# Altium schematic sheet ICWSHLP2_Adaptor_2x2_Transimpedance_ASIC0.SchDoc(ICWSHLP2_Adaptor_2x2_Transimpedance_ASIC0)
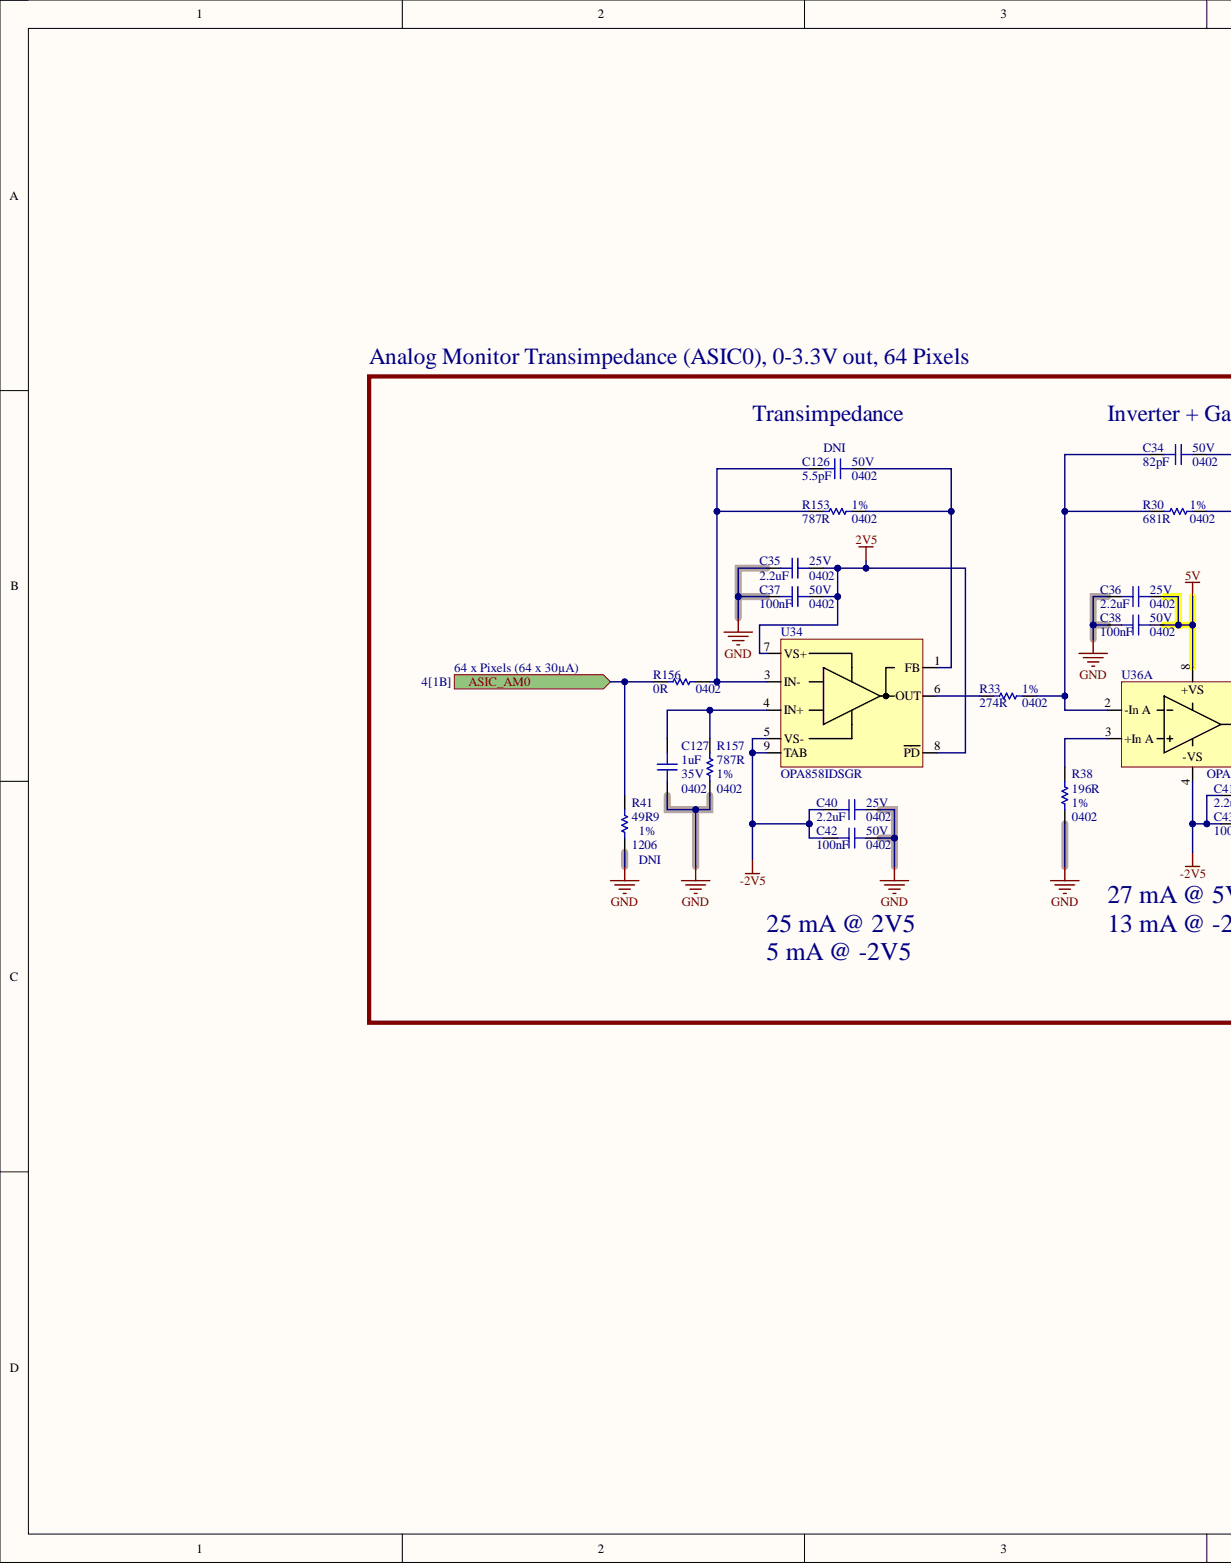
[diagram p0: ICWSHLP2_Adaptor_2x2_Transimpedance_ASIC0.SchDoc, left]
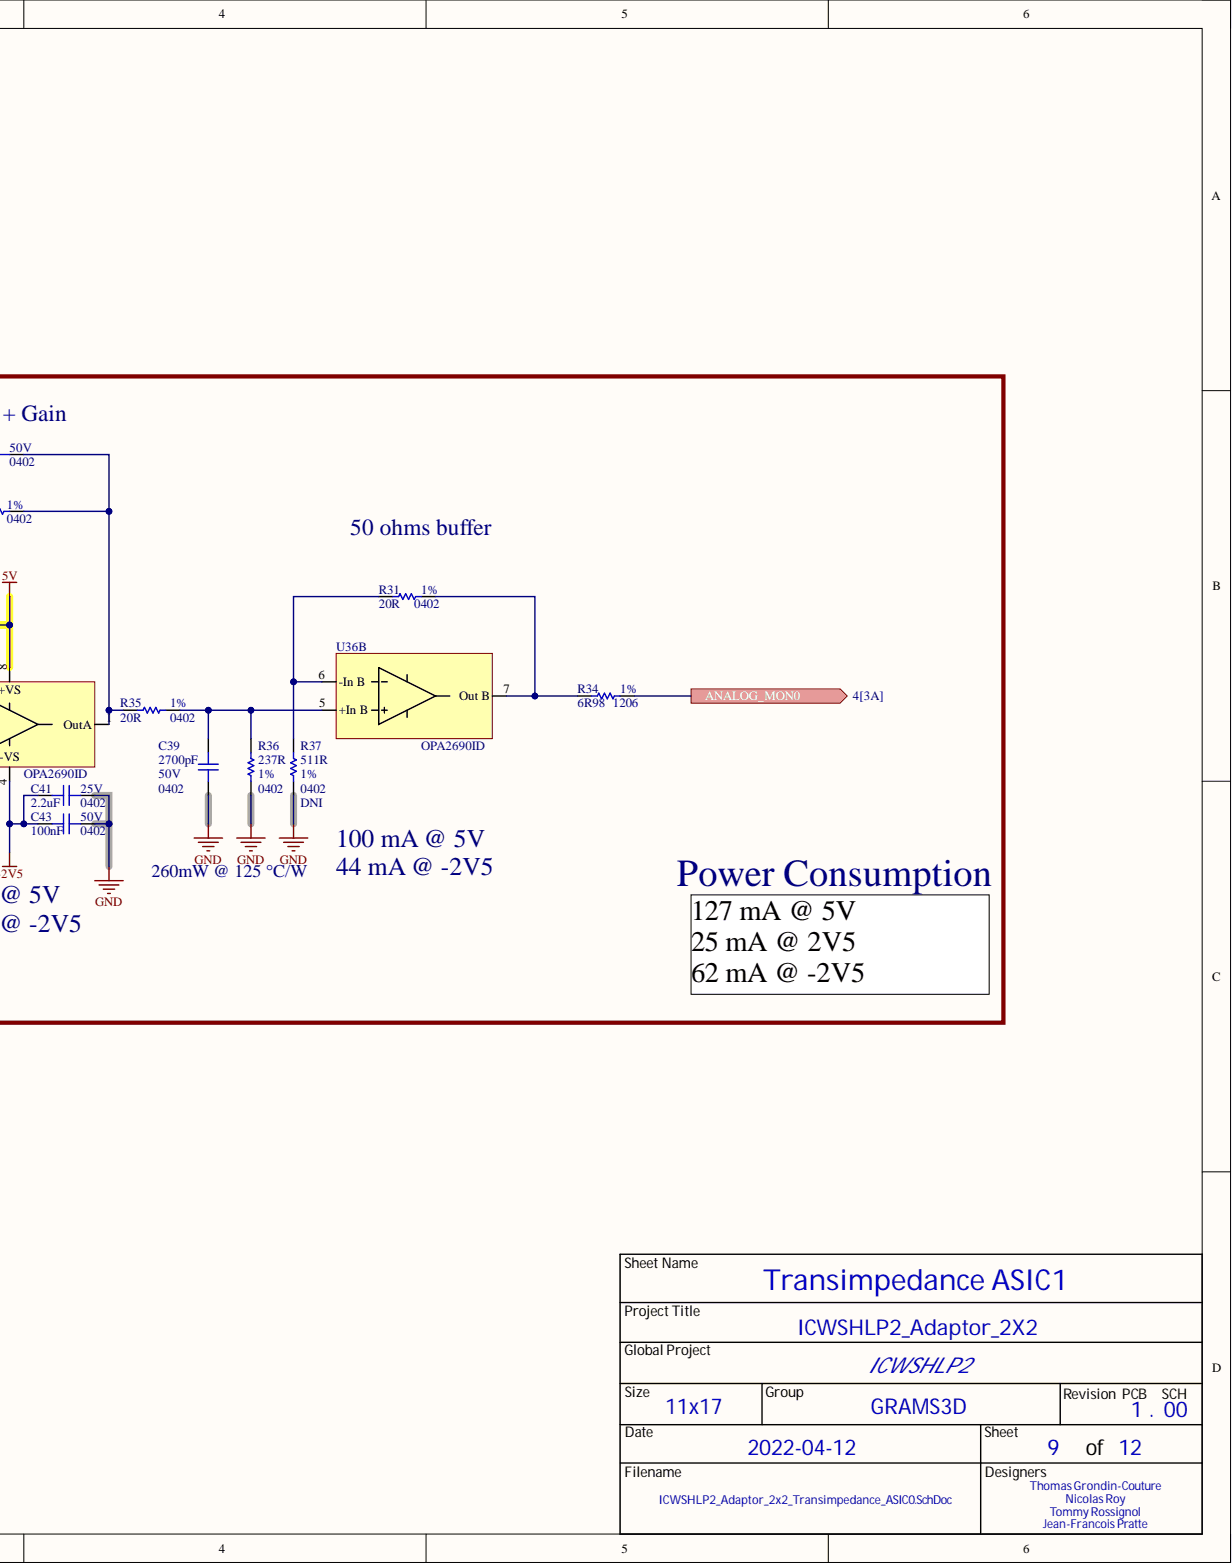
[diagram p1: ICWSHLP2_Adaptor_2x2_Transimpedance_ASIC0.SchDoc, right]

## Parts
C34  value 82pF  Package: 0402  Voltage Rating: 50V  [CAP_CER_0402]  (hidden comment: 0402N820J500CT)
C35  value 2.2uF  Package: 0402  Voltage Rating: 25V  [CAP_CER_0402]  (hidden comment: GRT155R61E225KE13D)
C36  value 2.2uF  Package: 0402  Voltage Rating: 25V  [CAP_CER_0402]  (hidden comment: GRT155R61E225KE13D)
C37  value 100nF  Package: 0402  Voltage Rating: 50V  [CAP_CER_0402]  (hidden comment: UMK105B7104KV-FR)
C38  value 100nF  Package: 0402  Voltage Rating: 50V  [CAP_CER_0402]  (hidden comment: UMK105B7104KV-FR)
C39  value 2700pF  Package: 0402  Voltage Rating: 50V  [CAP_CER_0402]  (hidden comment: C0402C272J5RAC7867)
C40  value 2.2uF  Package: 0402  Voltage Rating: 25V  [CAP_CER_0402]  (hidden comment: GRT155R61E225KE13D)
C41  value 2.2uF  Package: 0402  Voltage Rating: 25V  [CAP_CER_0402]  (hidden comment: GRT155R61E225KE13D)
C42  value 100nF  Package: 0402  Voltage Rating: 50V  [CAP_CER_0402]  (hidden comment: UMK105B7104KV-FR)
C43  value 100nF  Package: 0402  Voltage Rating: 50V  [CAP_CER_0402]  (hidden comment: UMK105B7104KV-FR)
C126  value 5.5pF  Assembly Note: DNI  Package: 0402  Voltage Rating: 50V  [CAP_CER_0402]  (hidden comment: GCQ1555C1H5R5BB01D)
C127  value 1uF  Voltage Rating: 35V  [CAP_CER_0402]  (hidden comment: CL05A105KL5NRNC)
R30  value 681R  Package: 0402  Tolerance: 1%  [RES_0402]  (hidden comment: RC0402FR-07681RL)
R31  value 20R  Package: 0402  Tolerance: 1%  [RES_0402]  (hidden comment: RC0402FR-0720RL)
R33  value 274R  Package: 0402  Tolerance: 1%  [RES_0402]  (hidden comment: ERJ-2RKF2740X)
R34  value 6R98  Note: 1%  Package: 1206  [RES_1206]  (hidden comment: RC1206FR-076R98L)
R35  value 20R  Package: 0402  Tolerance: 1%  [RES_0402]  (hidden comment: RC0402FR-0720RL)
R36  value 237R  Package: 0402  Tolerance: 1%  [RES_0402]  (hidden comment: ERJ-2RKF2370X)
R37  value 511R  Assembly Note: DNI  Package: 0402  Tolerance: 1%  [RES_0402]  (hidden comment: RC0402FR-07511RL)
R38  value 196R  Package: 0402  Tolerance: 1%  [RES_0402]  (hidden comment: RC0402FR-07196RL)
R41  value 49R9  Assembly Note: DNI  Package: 1206  Tolerance: 1%  [RES_1206]  (hidden comment: RNCP1206FTD49R9RC1206FR-076R98L)
R153  value 787R  Package: 0402  Tolerance: 1%  [RES_0402]  (hidden comment: RC0402FR-07787RL)
R156  value 0R  Package: 0402  [RES_0402]  (hidden comment: RC0402JR-070RL)
R157  value 787R  Package: 0402  Tolerance: 1%  [RES_0402]  (hidden comment: RC0402FR-07787RL)
U34  OPA858IDSGR  [AMP_HSP_OPA858IDSGR]
U36  OPA2690ID  [AMP_HSP_OPA2690ID]  (parts A,B)

## Ports
ANALOG_MON0, ASIC_AM0

## Nets
2V5: C35.2 C37.2 U34.7(VS+) U34.8(PD)
5V: C36.2 C38.2 U36.8
-2V5: C40.1 C41.1 C42.1 C43.1 U34.5(VS-) U34.9(TAB) U36.4
GND: C35.1 C36.1 C37.1 C38.1 C39.1 C40.2 C41.2 C42.2 C43.2 C127.1 R36.1 R37.1 R38.1 R41.1 R157.1
ANALOG_MON0: R34.1 ; port ANALOG_MON0
ASIC_AM0: R41.2 R156.2 ; port ASIC_AM0
NetC34_1: C34.1 R30.1 R35.2 U36.1(OutA)
NetC34_2: C34.2 R30.2 R33.1 U36.2(-In_A)
NetC39_2: C39.2 R35.1 R36.2 U36.5(+In_B)
NetC126_1: C126.1 R153.1 U34.1(FB)
NetC126_2: C126.2 R153.2 R156.1 U34.3(IN-)
NetC127_2: C127.2 R157.2 U34.4(IN+)
NetR31_1: R31.1 R34.2 U36.7(Out_B)
NetR31_2: R31.2 R37.2 U36.6(-In_B)
NetR33_2: R33.2 U34.6(OUT)
NetR38_2: R38.2 U36.3(+In_A)

## Library data of the parts
C34 = CAP_CER_0402N820J500CT: CAP CER 82PF 50V C0G/NP0 0402; Manufacturer #1: Walsin Technology Corporation; Cryo: UNKNOWN; GRAMS Lib Spec: 2.8; Polarized: NO; Tolerance: 5%; Assembly Type: SMD; Component Kind: Standard; HelpURL: http://www.passivecomponent.com/wp-content/uploads/2018/10/MLCC.pdf; Magnetic Material: UNKNOWN; Part Number #1: 0402N820J500CT; Solder Paste Type: UNKNOWN; Stencil Thickness (Recommended): UNKNOWN; Supplier 1: Digi-Key; Supplier Part Number 1: 1292-1355-1-ND; Temperature: -55°C ~ 125°C; CreatedBy/Date: Thomas G-C 2021-06-10; Library Name: Lib_temp_Thomas_GC.SchLib
C35 C36 C40 C41 = CAP_CER_GRT155R61E225KE13D: CAP - CER 2.2uF ±10% 25V X5R - 0402; Manufacturer #1: Murata Electronics North America; GRAMS Lib Spec: 2.8; Magnetic Material: Unknown; Note: 2uF@1.5V 1uF@5V 0.55uF@10V; Polarized: No; Assembly Type: SMD; ClassName: Transimpedance_ASIC0; Component Kind: Standard; HelpURL: http://search.murata.co.jp/Ceramy/image/img/A01X/G101/ENG/GRT155R61E225KE13-01.pdf; Library Name: GRAMS_MASTER_LIB.IntLib; Part Number #1: GRT155R61E225KE13D; Supplier 1: Digi-Key; Supplier Part Number 1: 490-12267-1-ND; Temperature: -55°C ~ 85°C; Tolerance: 10%; CreatedBy/Date: Keven Deslandes - 2018/12/18; VerifiedBy: Caroline Paulin - 23 janv 2019
C37 C38 C42 C43 = CAP_CER_UMK105B7104KV-FR: CAP - CER 100nF ±10% 50V X7R - 0402; Manufacturer #1: Taiyo Yuden; GRAMS Lib Spec: 2.8; Magnetic Material: Unknown; Note: DC bias losses = -25%@5V, -55%@10V; Polarized: No; Assembly Type: SMD; ClassName: Transimpedance_ASIC0; Component Kind: Standard; HelpURL: https://ds.yuden.co.jp/TYCOMPAS/ut/detail?pn=UMK105B7104KV-FR%20%20&u=M; Library Name: GRAMS_MASTER_LIB.IntLib; Part Number #1: UMK105B7104KV-FR; Supplier 1: Digi-Key; Supplier Part Number 1: 587-3498-1-ND; Temperature: -55°C ~ 125°C; Tolerance: 10%; CreatedBy/Date: Keven Deslandes - 2018/12/18; VerifiedBy: Caroline Paulin - 23 janv 2019
C39 = CAP_CER_C0402C272J5RAC7867: CAP CER 2700PF 50V X7R 0402; Manufacturer #1: KEMET; Cryo: UNKNOWN; GRAMS Lib Spec: 2.8; Polarized: NO; Tolerance: 5%; Assembly Type: SMD; Component Kind: Standard; HelpURL: https://content.kemet.com/datasheets/KEM_C1002_X7R_SMD.pdf; Magnetic Material: UNKNOWN; Part Number #1: C0402C272J5RAC7867; Solder Paste Type: UNKNOWN; Stencil Thickness (Recommended): UNKNOWN; Supplier 1: Digi-Key; Supplier Part Number 1: 399-14438-1-ND; Temperature: -55°C ~ 125°C; CreatedBy/Date: Thomas G-C 2021-06-10; Library Name: Lib_temp_Thomas_GC.SchLib
C126 = CAP_CER_GCQ1555C1H5R5BB01D: CAP - CER 5.5pF +/- 0.1pF 50V C0G/NPO - 0402; Manufacturer #1: Murata Electronics North America; GRAMS Lib Spec: 2.8; Magnetic Material: Unknown; Polarized: NO; Assembly Type: SMD; Component Kind: Standard; HelpURL: https://search.murata.co.jp/Ceramy/image/img/A01X/G101/ENG/GCQ1555C1H5R5BB01-01.pdf; Library Name: GRAMS_MASTER_LIB.IntLib; Part Number #1: GCQ1555C1H5R5BB01D; Supplier 1: Digi-Key; Supplier Part Number 1: 490-17488-1-ND; Temperature: -55°C ~ 125°C; Tolerance: 0.1pF; CreatedBy/Date: Nicolas Roy 2019-04-17
C127 = CAP_CER_CL05A105KL5NRNC: CAP - CER 1uF 10% 35V X5R - 0402; Manufacturer #1: Samsung Electro-Mechanics; Cryo: UNKNOWN; GRAMS Lib Spec: 2.8; Polarized: NO; Assembly Type: SMD; Component Kind: Standard; HelpURL: http://www.samsungsem.com/kr/support/product-search/mlcc/__icsFiles/afieldfile/2018/06/19/CL05A105KL5NRNC.pdf; Library Name: GRAMS_MASTER_LIB.IntLib; Magnetic Material: Unknown; Package: 0402; Part Number #1: CL05A105KL5NRNC; Supplier 1: Digi-Key; Supplier Part Number 1: 1276-6796-1-ND; Temperature: -55°C ~ 85°C; Tolerance: 10%; CreatedBy/Date: Nicolas Roy 2018-10-03; VerifiedBy: Caroline Paulin - 18 janv 2019
R30 = RES_RC0402FR-07681RL: RES - 681R 1% 1/16W - 0402; Manufacturer #1: Yageo; GRAMS Lib Spec: 2.8; Magnetic Material: Unknown; Polarized: No; Voltage Rating: 50V; Assembly Type: SMD; ClassName: Transimpedance_ASIC0; Component Kind: Standard; HelpURL: http://www.yageo.com/documents/recent/PYu-RC_Group_51_RoHS_L_10.pdf; Library Name: GRAMS_MASTER_LIB.IntLib; Part Number #1: RC0402FR-07681RL; Power Max: 1/16W; Supplier 1: Digi-Key; Supplier Part Number 1: YAG2375CT-ND; Temperature: -55°C ~ 155°C; CreatedBy/Date: Keven Deslandes 2018-11-02; VerifiedBy: Caroline Paulin - 8 janv 2019
R31 R35 = RES_RC0402FR-0720RL: RES - 20 OHM 1% 1/16W - 0402; Manufacturer #1: Yageo; Cryo: UNKNOWN; GRAMS Lib Spec: 2.8; Polarized: NO; Voltage Rating: 50V; Assembly Type: SMD; Component Kind: Standard; HelpURL: http://www.yageo.com/documents/recent/PYu-RC_Group_51_RoHS_L_10.pdf; Library Name: GRAMS_MASTER_LIB.IntLib; Magnetic Material: Unknown; Part Number #1: RC0402FR-0720RL; Power Max: 1/16W; Supplier 1: Digi-Key; Supplier Part Number 1: 311-20LRCT-ND; Temperature: -55°C ~ 155°C; CreatedBy/Date: Nicolas Roy/06 nov. 2018; VerifiedBy: Charles Richard 14-01-2019
R33 = RES_ERJ-2RKF2740X: RES - 274R 1% 1/10W - 0402; Manufacturer #1: Panasonic Electronic Components; GRAMS Lib Spec: 2.8; Magnetic Material: Unknown; Polarized: No; Voltage Rating: 50V; Assembly Type: SMD; ClassName: Transimpedance_ASIC0; Component Kind: Standard; HelpURL: https://industrial.panasonic.com/cdbs/www-data/pdf/RDA0000/AOA0000C304.pdf; Library Name: GRAMS_MASTER_LIB.IntLib; Part Number #1: ERJ-2RKF2740X; Power Max: 1/10W; Supplier 1: Digi-Key; Supplier Part Number 1: P274LCT-ND; Temperature: -55°C ~ 155°C; CreatedBy/Date: Keven Deslandes 2018-10-17; VerifiedBy: Caroline Paulin - 18/12/2018
R34 = RES_RC1206FR-076R98L: RES - 6R98 1% 1/4W  - 1206; Manufacturer #1: Yageo; Cryo: UNKNOWN; Current Rating: 2A; GRAMS Lib Spec: 2.9; Polarized: No; Voltage Rating: 200V; Assembly Type: SMD; Component Kind: Standard; HelpURL: https://www.yageo.com/upload/media/product/productsearch/datasheet/rchip/PYu-RC_Group_51_RoHS_L_11.pdf; Library Name: GRAMS_MASTER_LIB.IntLib; Magnetic Material: Unknown; Part Number #1: RC1206FR-076R98L; Power Max: 1/4W; Supplier 1: Digi-Key; Supplier Part Number 1: 311-6.98FRTR-ND; Temperature: -55°C ~ 155°C; CreatedBy/Date: Thomas Grondin-Couture 2020-09-30
R36 = RES_ERJ-2RKF2370X: RES - 237R 1% 1/10W - 0402; Manufacturer #1: Panasonic Electronic Components; GRAMS Lib Spec: 2.8; Magnetic Material: Unknown; Polarized: No; Voltage Rating: 50V; Assembly Type: SMD; ClassName: Transimpedance_ASIC0; Component Kind: Standard; HelpURL: https://industrial.panasonic.com/cdbs/www-data/pdf/RDA0000/AOA0000C304.pdf; Library Name: GRAMS_MASTER_LIB.IntLib; Part Number #1: ERJ-2RKF2370X; Power Max: 1/10W; Supplier 1: Digi-Key; Supplier Part Number 1: P237LCT-ND; Temperature: -55°C ~ 155°C; CreatedBy/Date: Keven Deslandes 2018-12-03; VerifiedBy: Caroline Paulin - 18/12/2018
R37 = RES_RC0402FR-07511RL: RES - 511R 1% 1/16W - 0402; Manufacturer #1: Yageo; GRAMS Lib Spec: 2.8; Magnetic Material: Unknown; Polarized: No; Voltage Rating: 50V; Assembly Type: SMD; ClassName: Transimpedance_ASIC0; Component Kind: Standard; HelpURL: http://www.yageo.com/documents/recent/PYu-RC_Group_51_RoHS_L_10.pdf; Library Name: GRAMS_MASTER_LIB.IntLib; Part Number #1: RC0402FR-07511RL; Power Max: 1/16W; Supplier 1: Digi-Key; Supplier Part Number 1: 311-511LRCT-ND; Temperature: -55°C ~ 155°C; CreatedBy/Date: Keven Deslandes 2018-11-02; VerifiedBy: Caroline Paulin - 8 janv 2019
R38 = RES_RC0402FR-07196RL: RES - 196R 1% 1/16W - 0402; Manufacturer #1: Yageo; Cryo: UNKNOWN; GRAMS Lib Spec: 2.8; Polarized: No; Voltage Rating: 50V; Assembly Type: SMD; Component Kind: Standard; HelpURL: http://www.yageo.com/documents/recent/PYu-RC_Group_51_RoHS_L_9.pdf; Library Name: GRAMS_MASTER_LIB.IntLib; Magnetic Material: Unknown; Part Number #1: RC0402FR-07196RL; Power Max: 1/16W; Supplier 1: Digi-Key; Supplier Part Number 1: YAG3025CT-ND; Temperature: -55°C ~ 155°C; CreatedBy/Date: Keven Deslandes - 2018-11-15; VerifiedBy: Caroline Paulin - 18/12/2018
R41 = RES_RNCP1206FTD49R9: RES - 49R9 1% 1/2W  - 1206; Manufacturer #1: Stackpole Electronics Inc.; Cryo: UNKNOWN; Current Rating: 2A; GRAMS Lib Spec: 2.9; Polarized: No; Power Max: 1/4W; Voltage Rating: 200V; Assembly Type: SMD; Component Kind: Standard; HelpURL: https://www.seielect.com/catalog/sei-rncp.pdf; Library Name: GRAMS_MASTER_LIB.IntLib; Magnetic Material: Unknown; Part Number #1: RNCP1206FTD49R9; Supplier 1: Digi-Key; Supplier Part Number 1: RNCP1206FTD49R9TR-ND; Temperature: -55°C ~ 155°C; CreatedBy/Date: Thomas Grondin-Couture 2020-09-30
R153 R157 = RES_RC0402FR-07787RL: RES - 787R 1% 1/16W - 0402; Manufacturer #1: Yageo; GRAMS Lib Spec: 2.8; Magnetic Material: Unknown; Polarized: No; Voltage Rating: 50V; Assembly Type: SMD; ClassName: Transimpedance_ASIC0; Component Kind: Standard; HelpURL: http://www.yageo.com/documents/recent/PYu-RC_Group_51_RoHS_L_10.pdf; Library Name: GRAMS_MASTER_LIB.IntLib; Part Number #1: RC0402FR-07787RL; Power Max: 1/16W; Supplier 1: Digi-Key; Supplier Part Number 1: YAG3233CT-ND; Temperature: -55°C ~ 155°C; CreatedBy/Date: Keven Deslandes 2018-11-20; VerifiedBy: Caroline Paulin - 8 janv 2019
R156 = RES_RC0402JR-070RL: RES - 0 OHM JUMPER 1/16W - 0402; Manufacturer #1: Yageo; GRAMS Lib Spec: 2.8; Magnetic Material: YES; Polarized: NO; Voltage Rating: 50V; Assembly Type: SMD; ClassName: Transimpedance_ASIC0; Component Kind: Standard; HelpURL: http://www.yageo.com/documents/recent/PYu-RC_Group_51_RoHS_L_9.pdf; Library Name: GRAMS_MASTER_LIB.IntLib; Part Number #1: RC0402JR-070RL; Power Max: 1/16W; Supplier 1: Digi-Key; Supplier Part Number 1: 311-0.0JRCT-ND; Temperature: -55°C ~ 155°C; Tolerance: N/A; CreatedBy/Date: Jonathan Bouchard 2018-07-05; VerifiedBy: Arnaud Samson 16-07-18
U34 = AMP_HSP_OPA858IDSGR: AMP - HSP 5.5GHZ LOW NOISE HIGH BW - WSON-8; Manufacturer #1: Texas Instruments; Cryo: UNKNOWN; Current Rating: 105mA; GRAMS Lib Spec: 2.9; Polarized: Yes; Voltage Rating: 3.3V~5.25V; Assembly Type: SMD; Component Kind: Standard; HelpURL: https://www.ti.com/lit/ds/symlink/opa858.pdf?ts=1600708573279&ref_url=https%253A%252F%252Fwww.ti.com%252Fproduct%252FOPA858; Library Name: GRAMS_MASTER_LIB.IntLib; Magnetic Material: Unknown; Package: WSON-8; Part Number #1: OPA858IDSGR; Supplier 1: Digi-Key; Supplier Part Number 1: 296-51512-2-ND; Temperature: -40°C ~ 125°C; CreatedBy/Date: Thomas Grondin-Couture - 2020/09/24; VerifiedBy: C.Paulin - 28-10-2020
U36 = AMP_HSP_OPA2690ID: AMP - Dual HIGH SPEED 220MHZ 1800V/us ±2.5V to ±6V CFB - 8-SO; Manufacturer #1: Texas Instruments; Cryo: UNKNOWN; Current Rating: 190mA; GRAMS Lib Spec: 2.9; Polarized: Yes; Voltage Rating: 5 V ~ 12 V, ±2.5 V ~ 6 V; Assembly Type: SMD; Component Kind: Standard; HelpURL: https://www.ti.com/lit/ds/symlink/opa2690.pdf?ts=1601046053325&ref_url=https%253A%252F%252Fwww.ti.com%252Famplifier-circuit%252Fop-amps%252Fhigh-speed%252Fproducts.html; Library Name: GRAMS_MASTER_LIB.IntLib; Magnetic Material: Unknown; Package: 8-SOIC; Part Number #1: OPA2690ID; Supplier 1: Digi-Key; Supplier Part Number 1: 296-13465-5-ND; Temperature: -40°C ~ 85°C; CreatedBy/Date: Thomas Grondin-Couture - 2020/09/25
